# Altium schematic sheet MCU_723.SchDoc(MCU_723)
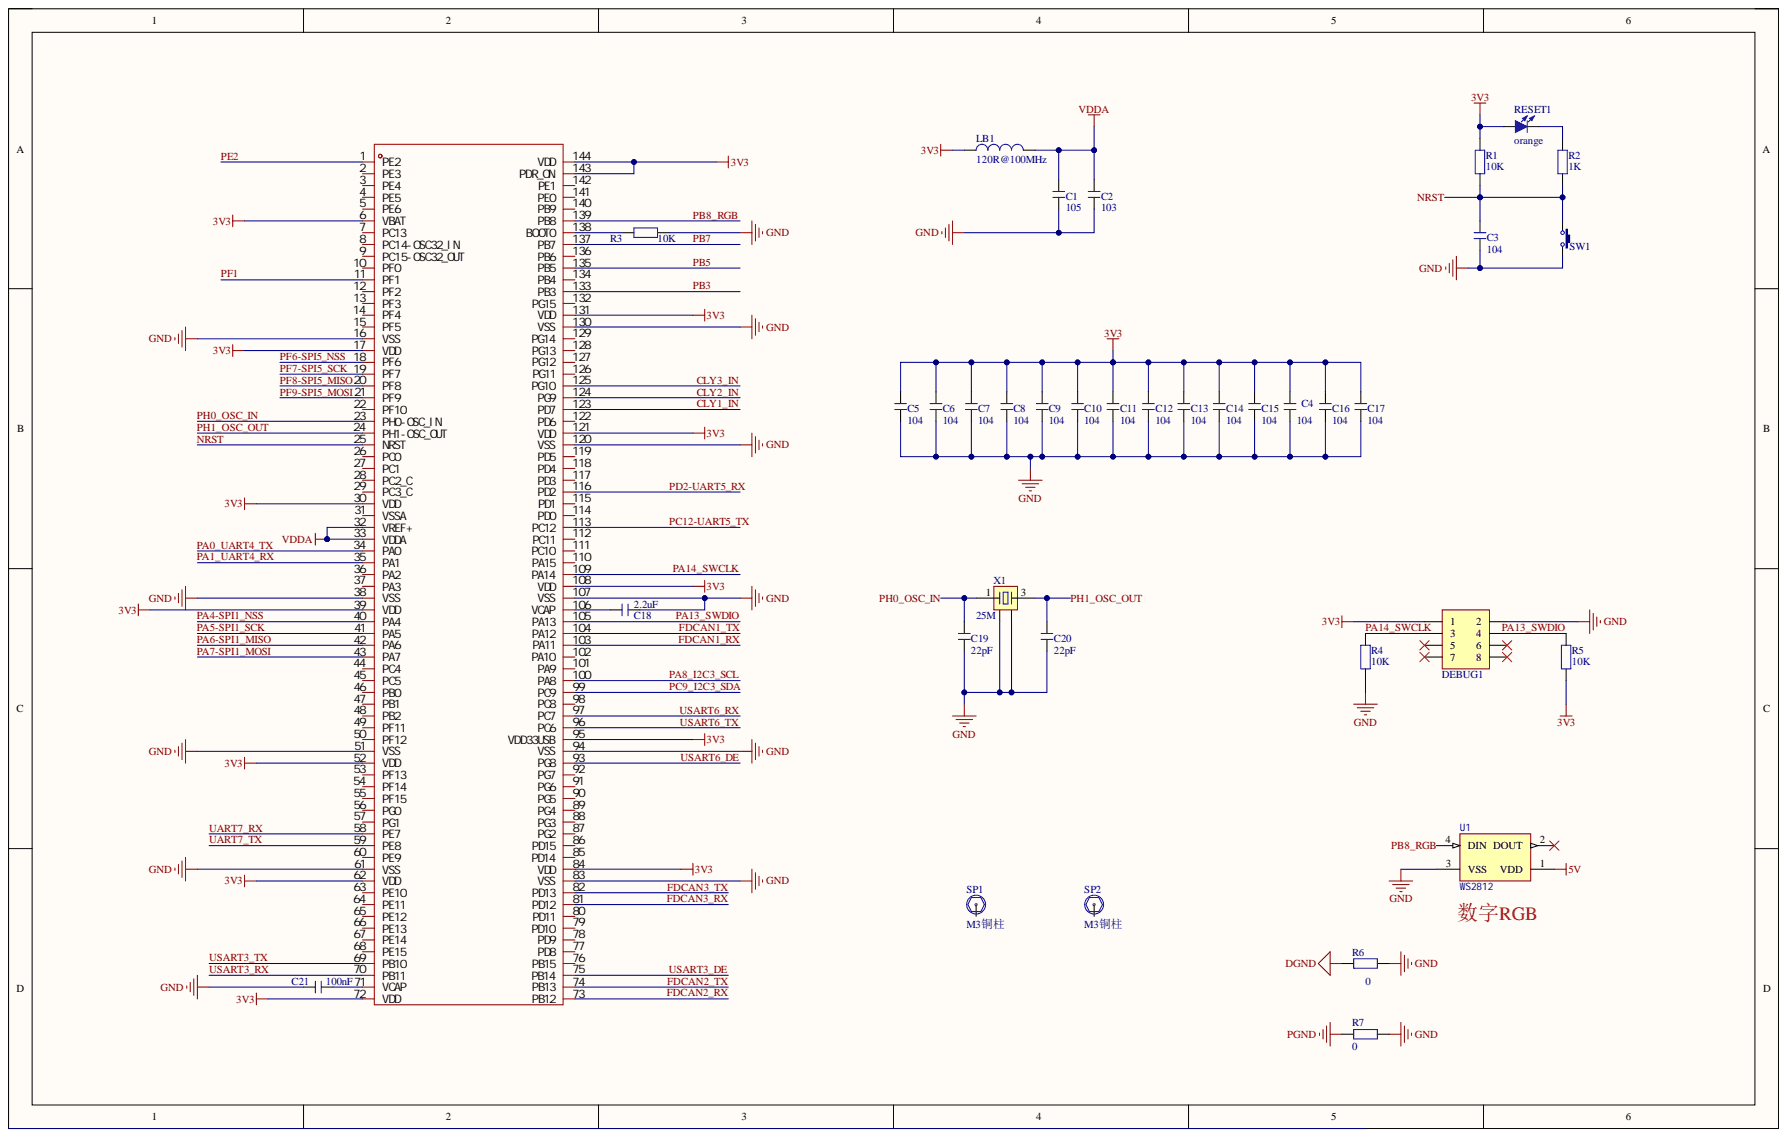
[diagram p0: MCU_723.SchDoc]

## Parts
C1  105  [C 0603_L]
C2  103  [C 0603_L]
C3  104  [C 0603_L]
C4  104  [C 0603_L]
C5  104  [C 0603_L]
C6  104  [C 0603_L]
C7  104  [C 0603_L]
C8  104  [C 0603_L]
C9  104  [C 0603_L]
C10  104  [C 0603_L]
C11  104  [C 0603_L]
C12  104  [C 0603_L]
C13  104  [C 0603_L]
C14  104  [C 0603_L]
C15  104  [C 0603_L]
C16  104  [C 0603_L]
C17  104  [C 0603_L]
C18  2.2uF  [C 0603_L]
C19  22pF  [C 0603_L]
C20  22pF  [C 0603_L]
C21  100nF  [C 0603_L]
DEBUG1  Header 4X2  [IDC2.54-LI-2x4P]  (value not drawn)
LB1  120R@100MHz  [FB 0603_L]
R1  10K  [R 0603_L]
R2  1K  [R 0603_L]
R3  10K  [R 0603_L]
R4  10K  [R 0603_L]
R5  10K  [R 0603_L]
R6  0  [R 0603_L]
R7  0  [R 0603_L]
RESET1  orange  [LED 0603R]
SP1  M3铜柱  [M3x4_L]
SP2  M3铜柱  [M3x4_L]
SW1  3x6x5  [TSW SMD-3*6*2.5]  (value not drawn)
U1  WS2812  [WS2812B_B]
U2  STM32H723ZGT6  [LQFP144 20X20_L]  (value not drawn)
X1  25M  [OSC 3225-4P]

## Nets
3V3: C4.2 C5.2 C6.2 C7.2 C8.2 C9.2 C10.2 C11.2 C12.2 C13.2 C14.2 C15.2 C16.2 C17.2 DEBUG1.1 LB1.1 R1.2 R5.1 RESET1.1 U2.6(VBAT) U2.17(VDD) U2.30(VDD) U2.39(VDD) U2.52(VDD) U2.62(VDD) U2.72(VDD) U2.84(VDD) U2.95(VDD33USB) U2.108(VDD) U2.121(VDD) U2.131(VDD) U2.143(PDR_ON) U2.144(VDD)
5V: U1.1(VDD)
DGND: R6.2
GND: C1.1 C2.1 C3.1 C4.1 C5.1 C6.1 C7.1 C8.1 C9.1 C10.1 C11.1 C12.1 C13.1 C14.1 C15.1 C16.1 C17.1 C18.2 C19.1 C20.1 C21.1 DEBUG1.2 R3.2 R4.1 R6.1 R7.2 SW1.2 U1.3(VSS) U2.16(VSS) U2.38(VSS) U2.51(VSS) U2.61(VSS) U2.83(VSS) U2.94(VSS) U2.107(VSS) U2.120(VSS) U2.130(VSS) X1.2 X1.4
PGND: R7.1
VDDA: C1.2 C2.2 LB1.2 U2.32(VREF+) U2.33(VDDA)
CLY1_IN: U2.123(PD7)
CLY2_IN: U2.124(PG9)
CLY3_IN: U2.125(PG10)
FDCAN1_RX: U2.103(PA11)
FDCAN1_TX: U2.104(PA12)
FDCAN2_RX: U2.73(PB12)
FDCAN2_TX: U2.74(PB13)
FDCAN3_RX: U2.81(PD12)
FDCAN3_TX: U2.82(PD13)
NRST: C3.2 R1.1 R2.1 SW1.1 U2.25(NRST)
PA0_UART4_TX: U2.34(PA0)
PA1_UART4_RX: U2.35(PA1)
PA4-SPI1_NSS: U2.40(PA4)
PA5-SPI1_SCK: U2.41(PA5)
PA6-SPI1_MISO: U2.42(PA6)
PA7-SPI1_MOSI: U2.43(PA7)
PA8_I2C3_SCL: U2.100(PA8)
PA13_SWDIO: DEBUG1.4 R5.2 U2.105(PA13)
PA14_SWCLK: DEBUG1.3 R4.2 U2.109(PA14)
PB3: U2.133(PB3)
PB5: U2.135(PB5)
PB7: U2.137(PB7)
PB8_RGB: U1.4(DIN) U2.139(PB8)
PC9_I2C3_SDA: U2.99(PC9)
PC12-UART5_TX: U2.113(PC12)
PD2-UART5_RX: U2.116(PD2)
PE2: U2.1(PE2)
PF1: U2.11(PF1)
PF6-SPI5_NSS: U2.18(PF6)
PF7-SPI5_SCK: U2.19(PF7)
PF8-SPI5_MISO: U2.20(PF8)
PF9-SPI5_MOSI: U2.21(PF9)
PH0_OSC_IN: C19.2 U2.23(PH0-OSC_IN) X1.1
PH1_OSC_OUT: C20.2 U2.24(PH1-OSC_OUT) X1.3
UART7_RX: U2.58(PE7)
UART7_TX: U2.59(PE8)
USART3_DE: U2.75(PB14)
USART3_RX: U2.70(PB11)
USART3_TX: U2.69(PB10)
USART6_DE: U2.93(PG8)
USART6_RX: U2.97(PC7)
USART6_TX: U2.96(PC6)
NetC18_1: C18.1 U2.106(VCAP)
NetC21_2: C21.2 U2.71(VCAP)
NetR2_2: R2.2 RESET1.2
NetR3_1: R3.1 U2.138(BOOT0)

Not connected: DEBUG1 5 6 7 8; SP1 1; SP2 1; U1 2(DOUT); U2 2(PE3) 3(PE4) 4(PE5) 5(PE6) 7(PC13) 8(PC14-OSC32_IN) 9(PC15-OSC32_OUT) 10(PF0) 12(PF2) 13(PF3) 14(PF4) 15(PF5) 22(PF10) 26(PC0) 27(PC1) 28(PC2_C) 29(PC3_C) 31(VSSA) 36(PA2) 37(PA3) 44(PC4) 45(PC5) 46(PB0) 47(PB1) 48(PB2) 49(PF11) 50(PF12) 53(PF13) 54(PF14) 55(PF15) 56(PG0) 57(PG1) 60(PE9) 63(PE10) 64(PE11) 65(PE12) 66(PE13) 67(PE14) 68(PE15) 76(PB15) 77(PD8) 78(PD9) 79(PD10) 80(PD11) 85(PD14) 86(PD15) 87(PG2) 88(PG3) 89(PG4) 90(PG5) 91(PG6) 92(PG7) 98(PC8) 101(PA9) 102(PA10) 110(PA15) 111(PC10) 112(PC11) 114(PD0) 115(PD1) 117(PD3) 118(PD4) 119(PD5) 122(PD6) 126(PG11) 127(PG12) 128(PG13) 129(PG14) 132(PG15) 134(PB4) 136(PB6) 140(PB9) 141(PE0) 142(PE1)

## Library data of the parts
C1-C21 = C: 无极性贴片电容; 材质: X7R; BOM名称: 无极性贴片电容; Component Kind: Standard; Library Name: 3.1   - 电阻-电容-电感.SchLib
DEBUG1 = Header 4X2: 4*2P接插件; BOM名称: 4*2P接插件; Component Kind: Standard; Library Name: 4.6   - 接插件-脚距2.54.SchLib
LB1 = LB: 磁珠; BOM名称: 磁珠; Component Kind: Standard; Library Name: 3.1   - 电阻-电容-电感.SchLib
R1-R7 = R: 贴片电阻; BOM名称: 贴片电阻; Component Kind: Standard; Library Name: 3.1   - 电阻-电容-电感.SchLib
RESET1 = LED-SMD: 贴片LED; BOM名称: 贴片LED; Component Kind: Standard; Library Name: 9.1   - LED.SchLib
SP1 SP2 = 铜柱小焊盘: 铜柱; BOM名称: 铜柱; Component Kind: Standard; Library Name: 15.1 - 调试、辅助器件.IntLib
SW1 = TSW 3x6: 3x6轻触开关; BOM名称: 3x6轻触开关; Component Kind: Standard; Library Name: 5.1   - 按键-开关.SchLib
U1 = WS2812: Intelligent Control LED Integrated Light Source, 3.5 to 5.3 V, -25 to 80 degC, 4-Pin SMD, RoHS, Bulk; PartNumber: WS2812B; Case\Package: Radial; Current Rating: 240 mA; Shielding: Unshielded; Tolerance: 10%; Component Kind: Standard; ComponentLink1Description: Manufacturer URL; ComponentLink1URL: https://www.adafruit.com/; ComponentLink2Description: Datasheet; ComponentLink2URL: https://cdn-shop.adafruit.com/datasheets/WS2812B.pdf; DatasheetVersion: May-13; Height: 12.2 mm; Lead Pitch: 5 mm; Length: 12.2 mm; Library Name: KEY.IntLib; Max Operating Temperature: 125 degC; Min Operating Temperature: -55 degC; Mount: Through Hole; Package Quantity: 200; PackageDescription: 4-Pin Surface Mount Device, Body 5 x 5 mm, Pitch 3.3 mm; PackageReference: WS2812B; Packaging: Bulk; Pins: 2; Radiation Hardening: No; REACH SVHC: No SVHC; RoHSCompliant: true
U2 = STM32H723ZGT6: Manufacturer: ST(意法半导体); ADC (Bit): 12bit；16bit; Add into BOM: yes; CPU Maximum Speed: 550MHz; DAC (Bit): 12bit; Direct Memory Access: 有; GPIO Ports Number: 112; Operating Voltage Range: 1.71V~3.6V; Peripheral/Function: DMA；LCD/LED驱动；PWM; Program Memory Type: FLASH; Program Storage Size: 1MB; RAM Size: 564KB; 8Bit Timer: -; 16Bit Timer: -; 32Bit Timer: -; Application Area: -; CAN: -; CCP Capture/Compare: -; communication protocol: -; Component Kind: Standard; Convert to PCB: yes; CPU Core: -; Datasheet: https://atta.szlcsc.com/upload/public/pdf/source/20200902/C730141_32325B298F902737689CA9A88D6BD2F2.pdf; Device: STM32H723ZGT6; I2C: -; I2S: -; Infrared Data Association: 有; Internal Comparator: -; Internal Oscillator: 有; JLCPCB Part Class: Extended Part; LCD Module: 有; LCSC Part Name: 高性能，带有DP-FPU的DSP，基于Arm Cortex-M7的MCU，配备1 M字节的Flash，564 KB的RAM，550 MHz的CPU，L1缓存，外部内存接口，部分外围设备子集; LED Module: -; Library Name: 2.1   - STM32系列单片机.SchLib; Low-Voltage Detect: 有; Manufacturer Part: STM32H723ZGT6; Operating Temperature Range: -40℃~+85℃; PWM (Bit): -; Real-Time Clock: -; Secure Digital Input and Output: 有; SPI: -; Supplier: LCSC; Supplier Footprint: LQFP-144(20x20); Supplier Part: C730146; Symbol: STM32H723ZGT6; UART/USART: -; Universal Serial Bus: 有; Watchdog: 有
X1 = XTAL-4P: 4脚无源晶振; 负载电容: CL20pF; BOM名称: 4脚无源晶振; Component Kind: Standard; Library Name: 10.1 - 保险丝-晶振.SchLib
